# Altium schematic sheet [2]-MCU_LPC1778FBD144.SchDoc([2]-MCU_LPC1778FBD144)
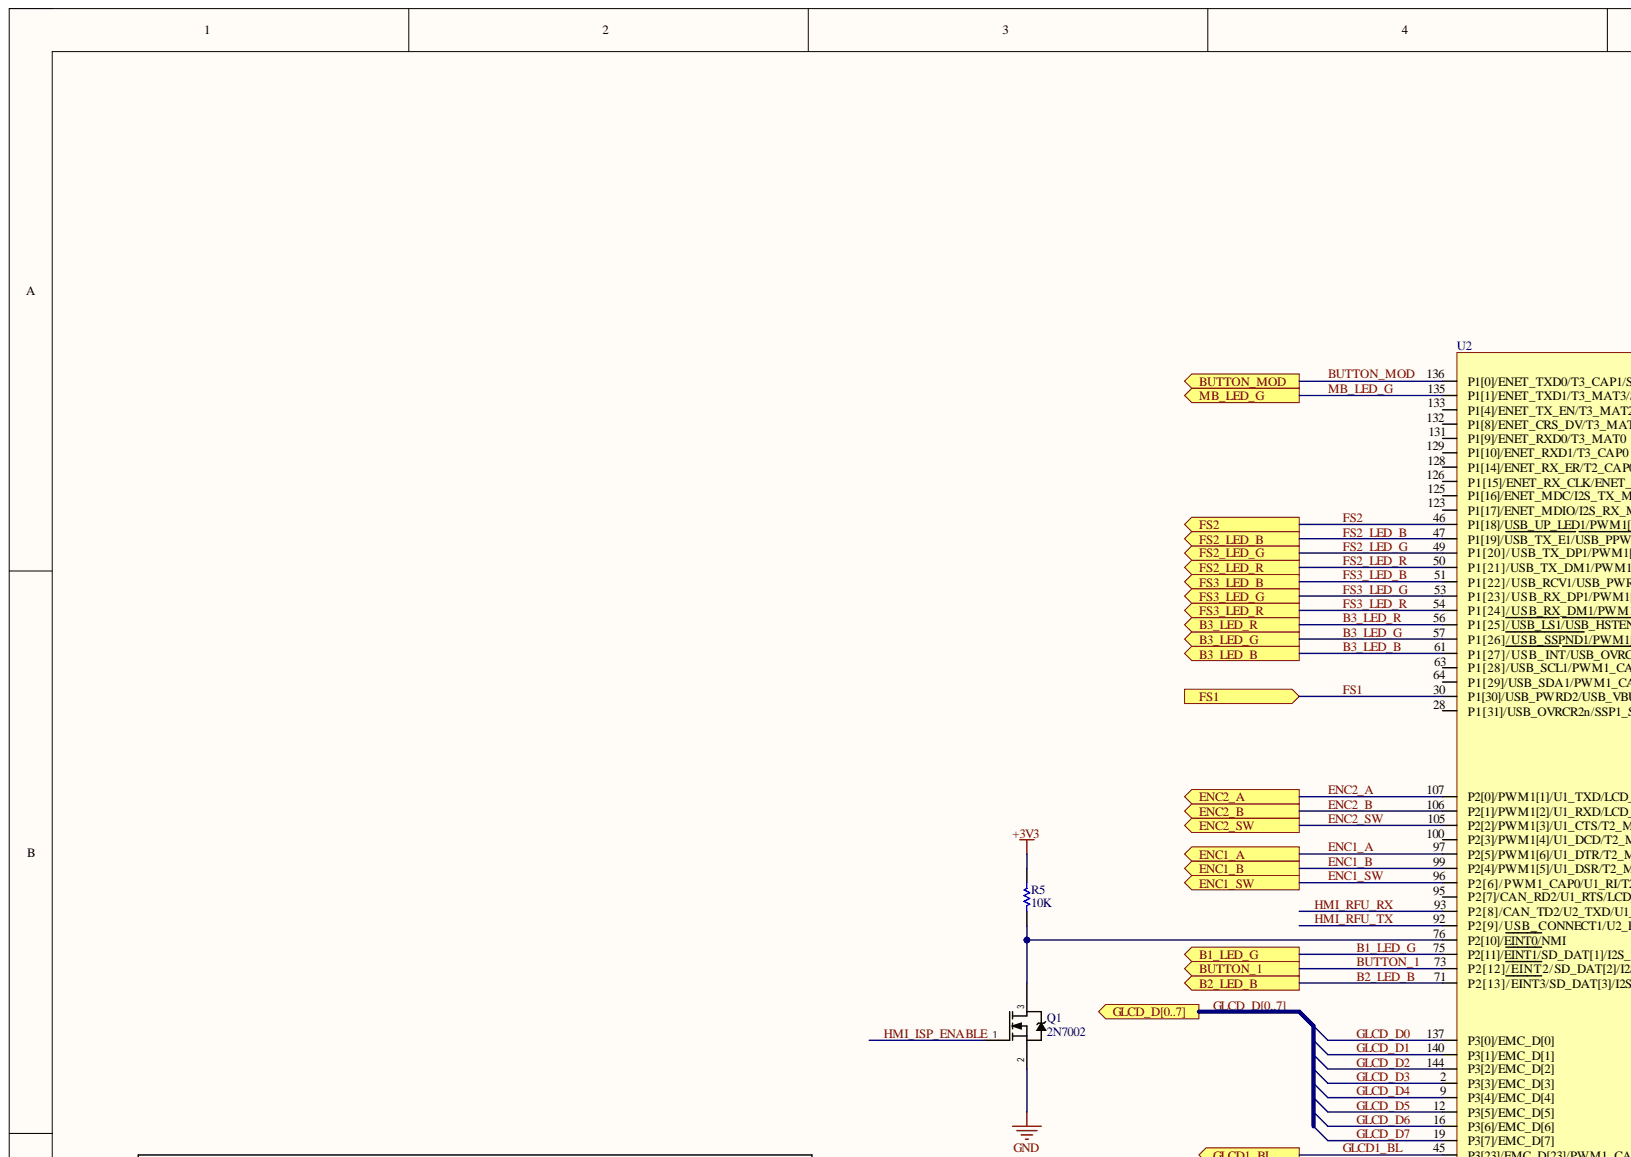
[diagram p0: [2]-MCU_LPC1778FBD144.SchDoc, top left]
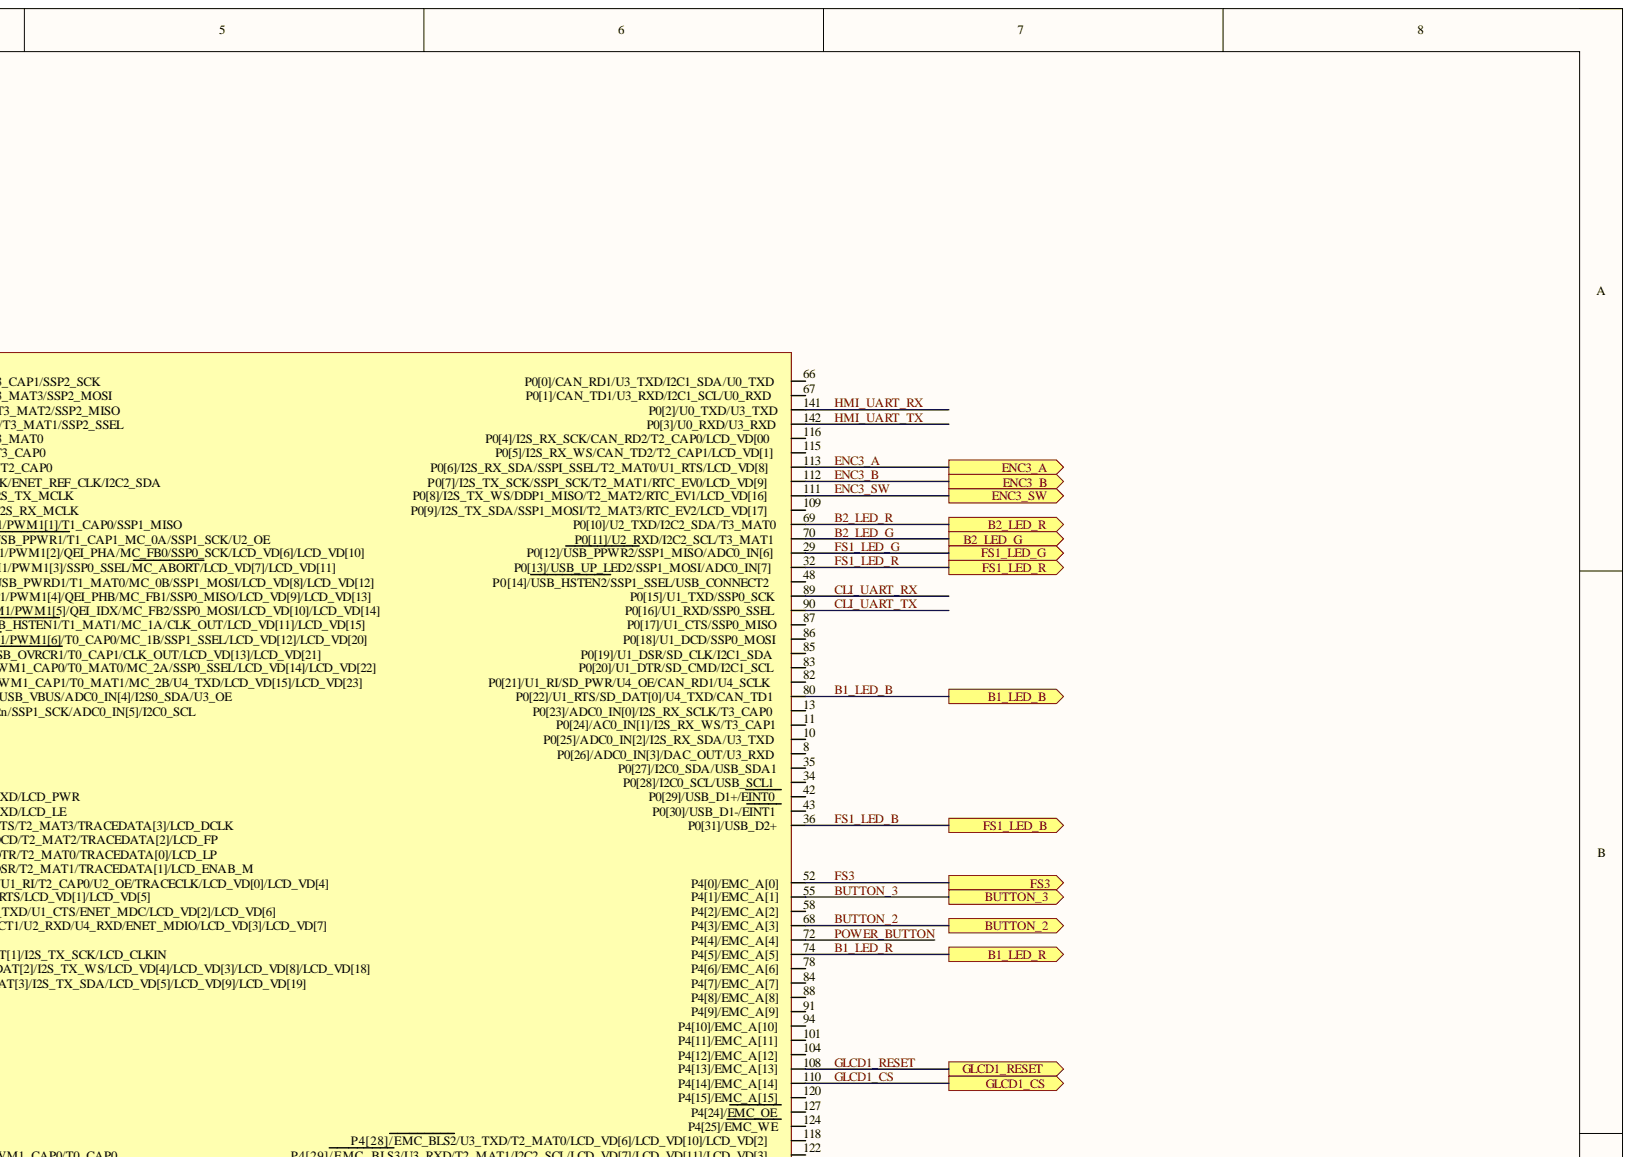
[diagram p1: [2]-MCU_LPC1778FBD144.SchDoc, top right]
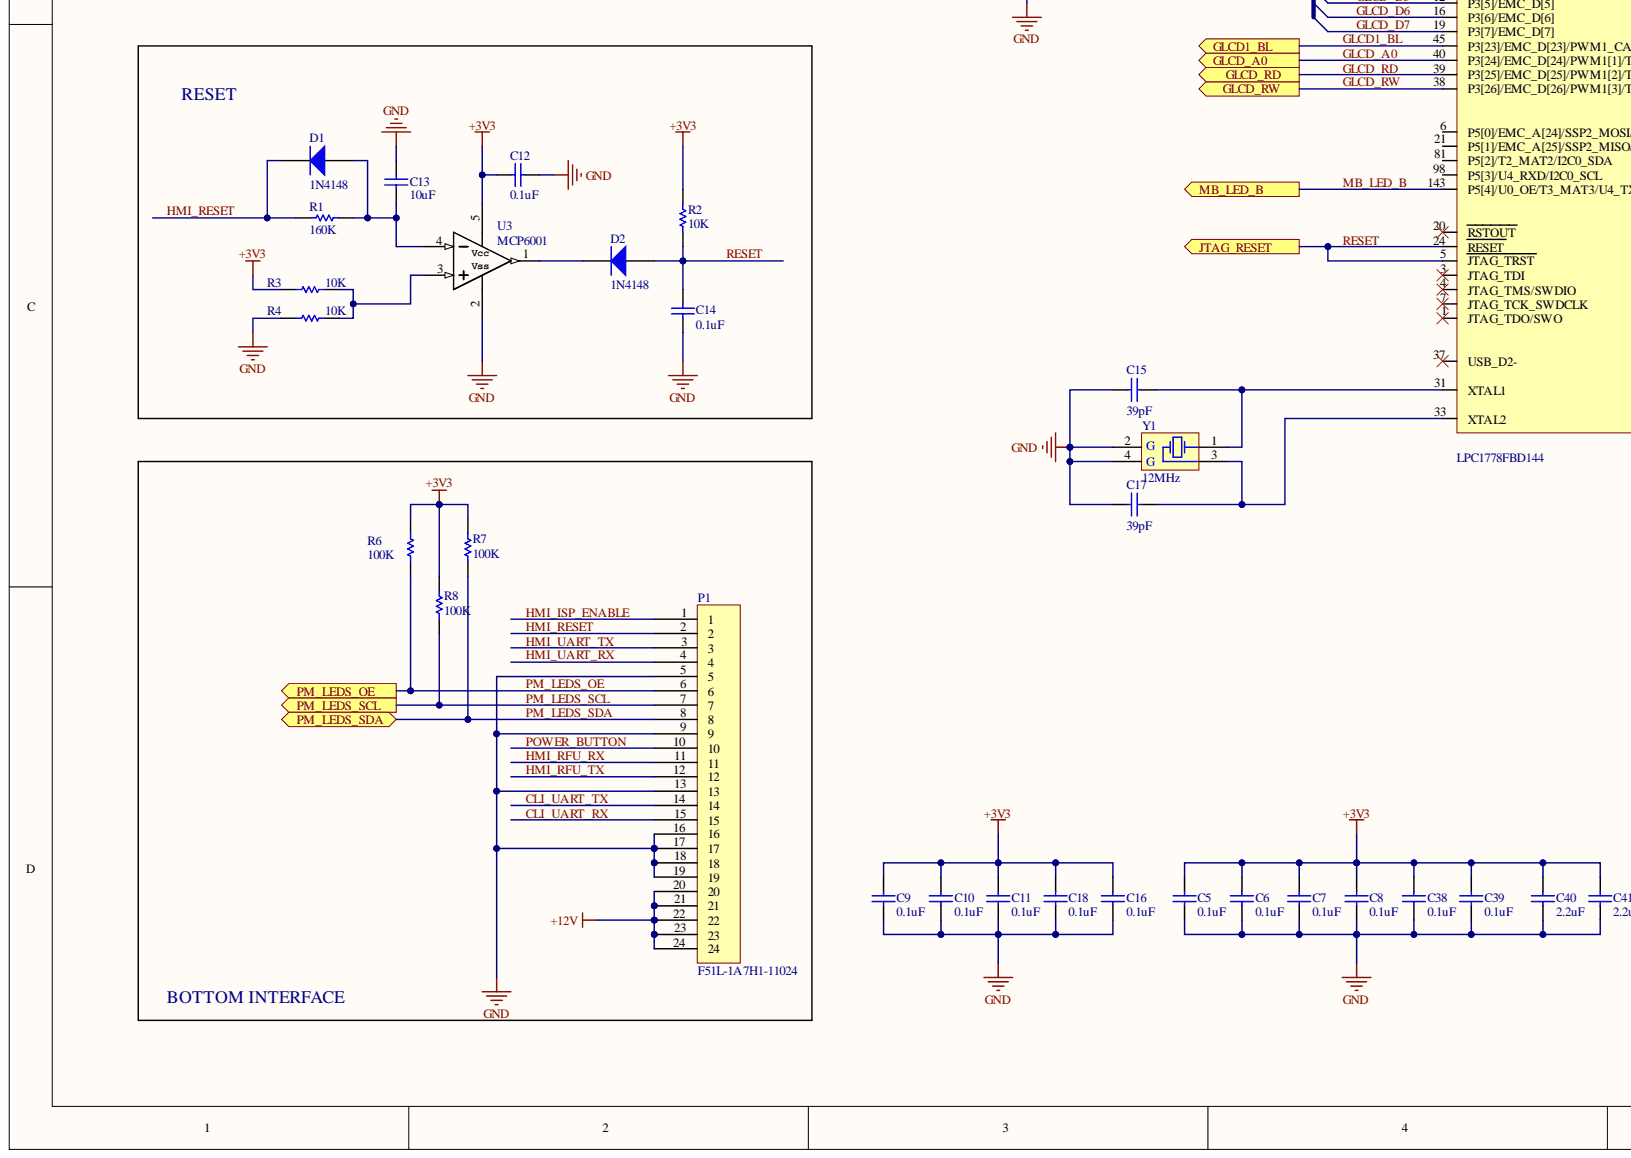
[diagram p2: [2]-MCU_LPC1778FBD144.SchDoc, bottom left]
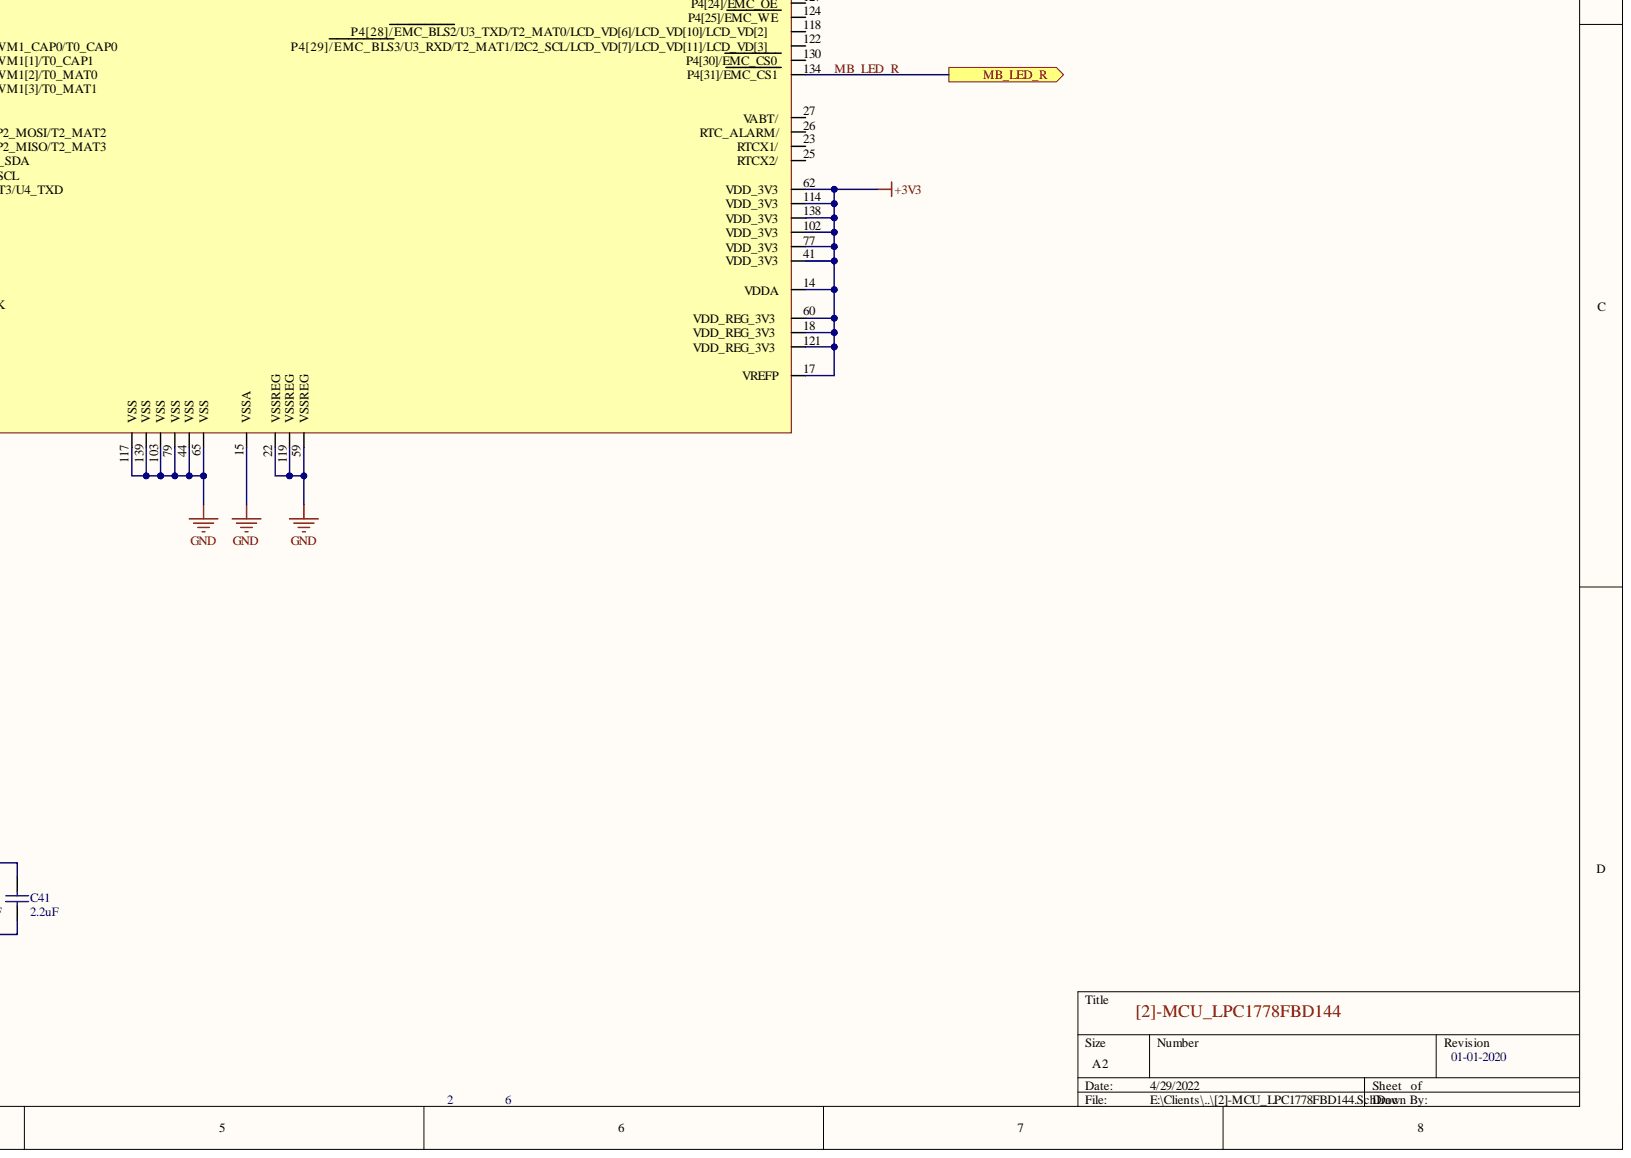
[diagram p3: [2]-MCU_LPC1778FBD144.SchDoc, bottom right]

## Parts
C5  0.1uF  [CAPC1608N]
C6  0.1uF  [CAPC1608N]
C7  0.1uF  [CAPC1608N]
C8  0.1uF  [CAPC1608N]
C9  0.1uF  [CAPC1608N]
C10  0.1uF  [CAPC1608N]
C11  0.1uF  [CAPC1608N]
C12  0.1uF  [CAPC1608N]
C13  10uF  [CAPC1608N]
C14  0.1uF  [CAPC1608N]
C15  39pF  [CAPC1608N]
C16  0.1uF  [CAPC1608N]
C17  39pF  [CAPC1608N]
C18  0.1uF  [CAPC1608N]
C38  0.1uF  [CAPC1608N]
C39  0.1uF  [CAPC1608N]
C40  2.2uF  [CAPC1608N]
C41  2.2uF  [CAPC1608N]
D1  1N4148  [SOD-323]
D2  1N4148  [SOD-323]
P1  F51L-1A7H1-11024  [F51L-1A7H1-11024]
Q1  2N7002  [SOT23-3N]
R1  160K  [RESC1608N]
R2  10K  [RESC1608N]
R3  10K  [RESC1608N]
R4  10K  [RESC1608N]
R5  10K  [RESC1608N]
R6  100K  [RESC1608N]
R7  100K  [RESC1608N]
R8  100K  [RESC1608N]
U2  LPC1778FBD144  [LQFP144-SOT486-1]
U3  MCP6001  [SOT23-5N]
Y1  12MHz  [ECS-120-20-30B-AGL-TR]

## Ports
B1_LED_B, B1_LED_G, B1_LED_R, B2_LED_B, B2_LED_G, B2_LED_R, B3_LED_B, B3_LED_G, B3_LED_R, BUTTON_1, BUTTON_2, BUTTON_3, BUTTON_MOD, ENC1_A, ENC1_B, ENC1_SW, ENC2_A, ENC2_B, ENC2_SW, ENC3_A, ENC3_B, ENC3_SW, FS1, FS1_LED_B, FS1_LED_G, FS1_LED_R, FS2, FS2_LED_B, FS2_LED_G, FS2_LED_R, FS3, FS3_LED_B, FS3_LED_G, FS3_LED_R, GLCD1_BL, GLCD1_CS, GLCD1_RESET, GLCD_A0, GLCD_D0, GLCD_D1, GLCD_D2, GLCD_D3, GLCD_D4, GLCD_D5, GLCD_D6, GLCD_D7, GLCD_D[0..7], GLCD_RD, GLCD_RW, JTAG_RESET, MB_LED_B, MB_LED_G, MB_LED_R, PM_LEDS_OE, PM_LEDS_SCL, PM_LEDS_SDA

## Nets
+3V3: C5.2 C6.2 C7.2 C8.2 C9.2 C10.2 C11.2 C12.2 C16.2 C18.2 C38.2 C39.2 C40.2 C41.2 R2.1 R3.2 R5.1 R6.2 R7.2 R8.2 U2.14(VDDA) U2.17(VREFP) U2.18(VDD_REG_3V3) U2.41(VDD_3V3) U2.60(VDD_REG_3V3) U2.62(VDD_3V3) U2.77(VDD_3V3) U2.102(VDD_3V3) U2.114(VDD_3V3) U2.121(VDD_REG_3V3) U2.138(VDD_3V3) U3.5
+12V: P1.20 P1.21 P1.22 P1.23 P1.24
GND: C5.1 C6.1 C7.1 C8.1 C9.1 C10.1 C11.1 C12.1 C13.1 C14.2 C15.2 C16.1 C17.1 C18.1 C38.1 C39.1 C40.1 C41.1 P1.5 P1.9 P1.13 P1.16 P1.17 P1.18 P1.19 Q1.2 R4.2 U2.15(VSSA) U2.22(VSSREG) U2.44(VSS) U2.59(VSSREG) U2.65(VSS) U2.79(VSS) U2.103(VSS) U2.117(VSS) U2.119(VSSREG) U2.139(VSS) U3.2 Y1.2(G) Y1.4(G)
B1_LED_B: U2.80(P0[22]/U1_RTS/SD_DAT[0]/U4_TXD/CAN_TD1) ; port B1_LED_B
B1_LED_G: U2.75(P2[11]/EINT1/SD_DAT[1]/I2S_TX_SCK/LCD_CLKIN) ; port B1_LED_G
B1_LED_R: U2.74(P4[5]/EMC_A[5]) ; port B1_LED_R
B2_LED_B: U2.71(P2[13]/_EINT3/SD_DAT[3]/I2S_TX_SDA/LCD_VD[5]/LCD_VD[9]/LCD_VD[19]) ; port B2_LED_B
B2_LED_G: U2.70(P0[11]/U2_RXD/I2C2_SCL/T3_MAT1) ; port B2_LED_G
B2_LED_R: U2.69(P0[10]/U2_TXD/I2C2_SDA/T3_MAT0) ; port B2_LED_R
B3_LED_B: U2.61(P1[27]/_USB__INT/USB_OVRCR1/T0_CAP1/CLK_OUT/LCD_VD[13]/LCD_VD[21]) ; port B3_LED_B
B3_LED_G: U2.57(P1[26]/_USB_SSPND1/PWM1[6]/T0_CAP0/MC_1B/SSP1_SSEL/LCD_VD[12]/LCD_VD[20]) ; port B3_LED_G
B3_LED_R: U2.56(P1[25]/_USB_LS1/USB_HSTEN1/T1_MAT1/MC_1A/CLK_OUT/LCD_VD[11]/LCD_VD[15]) ; port B3_LED_R
BUTTON_1: U2.73(P2[12]/_EINT2/_SD_DAT[2]/I2S_TX_WS/LCD_VD[4]/LCD_VD[3]/LCD_VD[8]/LCD_VD[18]) ; port BUTTON_1
BUTTON_2: U2.68(P4[3]/EMC_A[3]) ; port BUTTON_2
BUTTON_3: U2.55(P4[1]/EMC_A[1]) ; port BUTTON_3
BUTTON_MOD: U2.136(P1[0]/ENET_TXD0/T3_CAP1/SSP2_SCK) ; port BUTTON_MOD
CLI_UART_RX: P1.15 U2.89(P0[15]/U1_TXD/SSP0_SCK)
CLI_UART_TX: P1.14 U2.90(P0[16]/U1_RXD/SSP0_SSEL)
ENC1_A: U2.97(P2[5]/PWM1[6]/U1_DTR/T2_MAT0/TRACEDATA[0]/LCD_LP) ; port ENC1_A
ENC1_B: U2.99(P2[4]/PWM1[5]/U1_DSR/T2_MAT1/TRACEDATA[1]/LCD_ENAB_M) ; port ENC1_B
ENC1_SW: U2.96(P2[6]/_PWM1_CAP0/U1_RI/T2_CAP0/U2_OE/TRACECLK/LCD_VD[0]/LCD_VD[4]) ; port ENC1_SW
ENC2_A: U2.107(P2[0]/PWM1[1]/U1_TXD/LCD_PWR) ; port ENC2_A
ENC2_B: U2.106(P2[1]/PWM1[2]/U1_RXD/LCD_LE) ; port ENC2_B
ENC2_SW: U2.105(P2[2]/PWM1[3]/U1_CTS/T2_MAT3/TRACEDATA[3]/LCD_DCLK) ; port ENC2_SW
ENC3_A: U2.113(P0[6]/I2S_RX_SDA/SSPI_SSEL/T2_MAT0/U1_RTS/LCD_VD[8]) ; port ENC3_A
ENC3_B: U2.112(P0[7]/I2S_TX_SCK/SSPI_SCK/T2_MAT1/RTC_EV0/LCD_VD[9]) ; port ENC3_B
ENC3_SW: U2.111(P0[8]/I2S_TX_WS/DDP1_MISO/T2_MAT2/RTC_EV1/LCD_VD[16]) ; port ENC3_SW
FS1: U2.30(P1[30]/USB_PWRD2/USB_VBUS/ADC0_IN[4]/I2S0_SDA/U3_OE) ; port FS1
FS1_LED_B: U2.36(P0[31]/USB_D2+) ; port FS1_LED_B
FS1_LED_G: U2.29(P0[12]/USB_PPWR2/SSP1_MISO/ADC0_IN[6]) ; port FS1_LED_G
FS1_LED_R: U2.32(P0[13]/USB_UP_LED2/SSP1_MOSI/ADC0_IN[7]) ; port FS1_LED_R
FS2: U2.46(P1[18]/USB_UP_LED1/PWM1[1]/T1_CAP0/SSP1_MISO) ; port FS2
FS2_LED_B: U2.47(P1[19]/USB_TX_E1/USB_PPWR1/T1_CAP1_MC_0A/SSP1_SCK/U2_OE) ; port FS2_LED_B
FS2_LED_G: U2.49(P1[20]/_USB_TX_DP1/PWM1[2]/QEI_PHA/MC_FB0/SSP0_SCK/LCD_VD[6]/LCD_VD[10]) ; port FS2_LED_G
FS2_LED_R: U2.50(P1[21]/USB_TX_DM1/PWM1[3]/SSP0_SSEL/MC_ABORT/LCD_VD[7]/LCD_VD[11]) ; port FS2_LED_R
FS3: U2.52(P4[0]/EMC_A[0]) ; port FS3
FS3_LED_B: U2.51(P1[22]/_USB_RCV1/USB_PWRD1/T1_MAT0/MC_0B/SSP1_MOSI/LCD_VD[8]/LCD_VD[12]) ; port FS3_LED_B
FS3_LED_G: U2.53(P1[23]/_USB_RX_DP1/PWM1[4]/QEI_PHB/MC_FB1/SSP0_MISO/LCD_VD[9]/LCD_VD[13]) ; port FS3_LED_G
FS3_LED_R: U2.54(P1[24]/_USB_RX_DM1/PWM1[5]/QEI_IDX/MC_FB2/SSP0_MOSI/LCD_VD[10]/LCD_VD[14]) ; port FS3_LED_R
GLCD1_BL: U2.45(P3[23]/EMC_D[23]/PWM1_CAP0/T0_CAP0) ; port GLCD1_BL
GLCD1_CS: U2.110(P4[14]/EMC_A[14]) ; port GLCD1_CS
GLCD1_RESET: U2.108(P4[13]/EMC_A[13]) ; port GLCD1_RESET
GLCD_A0: U2.40(P3[24]/EMC_D[24]/PWM1[1]/T0_CAP1) ; port GLCD_A0
GLCD_D0: U2.137(P3[0]/EMC_D[0]) ; port GLCD_D[0..7]  [also labelled GLCD_D]
GLCD_D1: U2.140(P3[1]/EMC_D[1]) ; port GLCD_D[0..7]  [also labelled GLCD_D]
GLCD_D2: U2.144(P3[2]/EMC_D[2]) ; port GLCD_D[0..7]  [also labelled GLCD_D]
GLCD_D3: U2.2(P3[3]/EMC_D[3]) ; port GLCD_D[0..7]  [also labelled GLCD_D]
GLCD_D4: U2.9(P3[4]/EMC_D[4]) ; port GLCD_D[0..7]  [also labelled GLCD_D]
GLCD_D5: U2.12(P3[5]/EMC_D[5]) ; port GLCD_D[0..7]  [also labelled GLCD_D]
GLCD_D6: U2.16(P3[6]/EMC_D[6]) ; port GLCD_D[0..7]  [also labelled GLCD_D]
GLCD_D7: U2.19(P3[7]/EMC_D[7]) ; port GLCD_D[0..7]  [also labelled GLCD_D]
GLCD_RD: U2.39(P3[25]/EMC_D[25]/PWM1[2]/T0_MAT0) ; port GLCD_RD
GLCD_RW: U2.38(P3[26]/EMC_D[26]/PWM1[3]/T0_MAT1) ; port GLCD_RW
HMI_ISP_ENABLE: P1.1 Q1.1
HMI_RESET: D1.1 P1.2 R1.1
HMI_RFU_RX: P1.11 U2.93(P2[8]/CAN_TD2/U2_TXD/U1_CTS/ENET_MDC/LCD_VD[2]/LCD_VD[6])
HMI_RFU_TX: P1.12 U2.92(P2[9]/_USB__CONNECT1/U2_RXD/U4_RXD/ENET_MDIO/LCD_VD[3]/LCD_VD[7])
HMI_UART_RX: P1.4 U2.141(P0[2]/U0_TXD/U3_TXD)
HMI_UART_TX: P1.3 U2.142(P0[3]/U0_RXD/U3_RXD)
MB_LED_B: U2.143(P5[4]/U0_OE/T3_MAT3/U4_TXD) ; port MB_LED_B
MB_LED_G: U2.135(P1[1]/ENET_TXD1/T3_MAT3/SSP2_MOSI) ; port MB_LED_G
MB_LED_R: U2.134(P4[31]/EMC_CS1) ; port MB_LED_R
PM_LEDS_OE: P1.6 R6.1 ; port PM_LEDS_OE
PM_LEDS_SCL: P1.7 R8.1 ; port PM_LEDS_SCL
PM_LEDS_SDA: P1.8 R7.1 ; port PM_LEDS_SDA
POWER_BUTTON: P1.10 U2.72(P4[4]/EMC_A[4])
RESET: C14.1 D2.2 R2.2 U2.5(JTAG_TRST) U2.24(RESET) ; port JTAG_RESET
NetC13_2: C13.2 D1.2 R1.2 U3.4
NetC15_1: C15.1 U2.31(XTAL1) Y1.1
NetC17_2: C17.2 U2.33(XTAL2) Y1.3
NetD2_1: D2.1 U3.1
NetQ1_3: Q1.3 R5.2 U2.76(P2[10]/EINT0/NMI)
NetR3_1: R3.1 R4.1 U3.3

Not connected: U2 1(JTAG_TDO/SWO) 3(JTAG_TDI) 4(JTAG_TMS/SWDIO) 6(P5[0]/EMC_A[24]/SSP2_MOSI/T2_MAT2) 7(JTAG_TCK_SWDCLK) 8(P0[26]/ADC0_IN[3]/DAC_OUT/U3_RXD) 10(P0[25]/ADC0_IN[2]/I2S_RX_SDA/U3_TXD) 11(P0[24]/AC0_IN[1]/I2S_RX_WS/T3_CAP1) 13(P0[23]/ADC0_IN[0]/I2S_RX_SCLK/T3_CAP0) 20(RSTOUT) 21(P5[1]/EMC_A[25]/SSP2_MISO/T2_MAT3) 23(RTCX1/) 25(RTCX2/) 26(RTC_ALARM/) 27(VABT/) 28(P1[31]/USB_OVRCR2n/SSP1_SCK/ADC0_IN[5]/I2C0_SCL) 34(P0[28]/I2C0_SCL/USB_SCL1) 35(P0[27]/I2C0_SDA/USB_SDA1) 37(USB_D2-) 42(P0[29]/USB_D1+/EINT0) 43(P0[30]/USB_D1-/EINT1) 48(P0[14]/USB_HSTEN2/SSP1_SSEL/USB_CONNECT2) 58(P4[2]/EMC_A[2]) 63(P1[28]/USB_SCL1/PWM1_CAP0/T0_MAT0/MC_2A/SSP0_SSEL/LCD_VD[14]/LCD_VD[22]) 64(P1[29]/USB_SDA1/PWM1_CAP1/T0_MAT1/MC_2B/U4_TXD/LCD_VD[15]/LCD_VD[23]) 66(P0[0]/CAN_RD1/U3_TXD/I2C1_SDA/U0_TXD) 67(P0[1]/CAN_TD1/U3_RXD/I2C1_SCL/U0_RXD) 78(P4[6]/EMC_A[6]) 81(P5[2]/T2_MAT2/I2C0_SDA) 82(P0[21]/U1_RI/SD_PWR/U4_OE/CAN_RD1/U4_SCLK) 83(P0[20]/U1_DTR/SD_CMD/I2C1_SCL) 84(P4[7]/EMC_A[7]) 85(P0[19]/U1_DSR/SD_CLK/I2C1_SDA) 86(P0[18]/U1_DCD/SSP0_MOSI) 87(P0[17]/U1_CTS/SSP0_MISO) 88(P4[8]/EMC_A[8]) 91(P4[9]/EMC_A[9]) 94(P4[10]/EMC_A[10]) 95(P2[7]/CAN_RD2/U1_RTS/LCD_VD[1]/LCD_VD[5]) 98(P5[3]/U4_RXD/I2C0_SCL) 100(P2[3]/PWM1[4]/U1_DCD/T2_MAT2/TRACEDATA[2]/LCD_FP) 101(P4[11]/EMC_A[11]) 104(P4[12]/EMC_A[12]) 109(P0[9]/I2S_TX_SDA/SSP1_MOSI/T2_MAT3/RTC_EV2/LCD_VD[17]) 115(P0[5]/I2S_RX_WS/CAN_TD2/T2_CAP1/LCD_VD[1]) 116(P0[4]/I2S_RX_SCK/CAN_RD2/T2_CAP0/LCD_VD[00) 118(P4[28]/_EMC_BLS2/U3_TXD/T2_MAT0/LCD_VD[6]/LCD_VD[10]/LCD_VD[2]) 120(P4[15]/EMC_A[15]) 122 123(P1[17]/ENET_MDIO/I2S_RX_MCLK) 124(P4[25]/EMC_WE) 125(P1[16]/ENET_MDC/I2S_TX_MCLK) 126(P1[15]/ENET_RX_CLK/ENET_REF_CLK/I2C2_SDA) 127(P4[24]/EMC_OE) 128(P1[14]/ENET_RX_ER/T2_CAP0) 129(P1[10]/ENET_RXD1/T3_CAP0) 130(P4[30]/EMC_CS0) 131(P1[9]/ENET_RXD0/T3_MAT0) 132(P1[8]/ENET_CRS_DV/T3_MAT1/SSP2_SSEL) 133(P1[4]/ENET_TX_EN/T3_MAT2/SSP2_MISO)

## Library data of the parts
C5-C12 C14 C16 C18 C38 C39 = CC0603KRX7R8BB104: CAP CER 0.1UF 25V X7R 0603; Manufacturer 1: Yageo; Capacitance: 100nF; Tolerance: 10%; Case Code (Imperial): 0603; Case Code (Metric): 1608; Case/Package: 0603; Component Kind: Standard; ComponentLink1Description: https://datasheet.ciiva.com/11786/0900766b80c10176-11786120.pdf; ComponentLink1URL: https://datasheet.ciiva.com/11786/0900766b80c10176-11786120.pdf; ComponentLink2Description: https://datasheet.ciiva.com/144/mlccorderingcode-144075.pdf; ComponentLink2URL: https://datasheet.ciiva.com/144/mlccorderingcode-144075.pdf; ComponentLink3Description: https://datasheet.ciiva.com/17434/upy-gphc-x7r-6-3v-to-50v-8-17434263.pdf; ComponentLink3URL: https://datasheet.ciiva.com/17434/upy-gphc-x7r-6-3v-to-50v-8-17434263.pdf; ComponentLink4Description: https://datasheet.ciiva.com/26829/getdatasheetpartid-403577-26829431.pdf; ComponentLink4URL: https://datasheet.ciiva.com/26829/getdatasheetpartid-403577-26829431.pdf; ComponentLink5Description: https://datasheet.ciiva.com/11587/upy-gphc-x7r-6-3v-to-50v-8-11587208.pdf; ComponentLink5URL: https://datasheet.ciiva.com/11587/upy-gphc-x7r-6-3v-to-50v-8-11587208.pdf; ComponentLink6Description: https://datasheet.ciiva.com/13389/upy-gphc-x7r-6-3v-to-50v-8-13389352.pdf; ComponentLink6URL: https://datasheet.ciiva.com/13389/upy-gphc-x7r-6-3v-to-50v-8-13389352.pdf; ComponentLink7Description: https://datasheet.ciiva.com/28450/1489800-28450584.pdf; ComponentLink7URL: https://datasheet.ciiva.com/28450/1489800-28450584.pdf; ComponentLink8Description: https://datasheet.ciiva.com/144/mlccorderingcode-144073.pdf; ComponentLink8URL: https://datasheet.ciiva.com/144/mlccorderingcode-144073.pdf; ComponentLink9Description: https://datasheet.ciiva.com/144/mlccorderingcode-144074.pdf; ComponentLink9URL: https://datasheet.ciiva.com/144/mlccorderingcode-144074.pdf; ComponentLink10Description: https://datasheet.ciiva.com/7978/1489800-7978155.pdf; ComponentLink10URL: https://datasheet.ciiva.com/7978/1489800-7978155.pdf; Composition: Ceramic; Depth: 0.8mm; Dielectric: X7R; Halogen Free: Halogen Free; Height: 0.8mm; Lead Free: Lead Free; Length: 1.6mm; Manufacturer Part Number 1: CC0603KRX7R8BB104; Max Operating Temperature: 125°C; Min Operating Temperature: -55°C; Mount: Surface Mount; Number of Pins: 2; Package Quantity: 1; Packaging: Tape and Reel; Pricing 1: 1=0.1, 10=0.074, 100=0.0329, 500=0.02352, 1000=0.01848 (USD); Radiation Hardening: No; REACH SVHC: Unknown; Stock 1: 40000; Supplier 1: Digi-Key; Supplier Part Number 1: 311-1341-1-ND; Termination: SMD/SMT; Thickness: 0.035inch; Voltage: 25V; Voltage Rating: 25V; Voltage Rating (DC): 25V; Width: 0.8mm; Library Name: bitsnio.SchLib
C13 = GRM188R61A106KE69D: CAP CER 10UF 10V X5R 0603; Manufacturer 1: Murata; Tolerance: 10%; Capacitance: 10uF; Case Code (Imperial): 0603; Case Code (Metric): 1608; Case/Package: 0603; Component Kind: Standard; Depth: 0.8mm; Dielectric: X5R; Height: 0.8mm; Lead Free: Lead Free; Length: 1.6mm; Manufacturer Part Number 1: GRM188R61A106KE69D; Max Operating Temperature: 85°C; Min Operating Temperature: -55°C; Mount: Surface Mount; Packaging: Tape and Reel; Pricing 1: 1=0.22, 10=0.149, 100=0.0754, 500=0.05158, 1000=0.04563 (USD); REACH SVHC: No SVHC; Stock 1: 40000; Supplier 1: Digi-Key; Supplier Part Number 1: 490-10474-1-ND; Thickness: 0.037inch; Voltage: 10V; Voltage Rating: 10V; Voltage Rating (DC): 10V; Width: 0.031inch; Library Name: bitsnio.SchLib
C15 C17 = C1608NP01H390J080AA: CAP CER 39PF 50V NP0 0603; Manufacturer 1: TDK; Dielectric: C0G; Tolerance: 5%; Capacitance: 39pF; Case Code (Imperial): 0603; Case Code (Metric): 1608; Case/Package: 0603; Component Kind: Standard; Height: 0.8mm; Length: 0.063inch; Manufacturer Part Number 1: C1608NP01H390J080AA; Max Operating Temperature: 150°C; Min Operating Temperature: -55°C; Mount: Surface Mount; Package Quantity: 4000; Packaging: Tape and Reel; Pricing 1: 1=0.15, 10=0.106, 100=0.05, 500=0.03508, 1000=0.02904 (USD); Stock 1: 1503; Supplier 1: Digi-Key; Supplier Part Number 1: 445-14077-1-ND; Termination: SMD/SMT; Thickness: 0.035inch; Voltage Rating: 50V; Voltage Rating (DC): 50V; Weight: 7.1E-05oz; Width: 0.031inch; Library Name: bitsnio.SchLib
C40 C41 = CL10A225KP8NNNC: CAP CER 2.2UF 10V X5R 0603; Manufacturer 1: Samsung; Material: Ceramic; Tolerance: 10%; Capacitance: 2.2uF; Case Code (Imperial): 0603; Case Code (Metric): 1608; Case/Package: 0603; Component Kind: Standard; ComponentLink1Description: https://datasheet.ciiva.com/25799/cl10a225kp8nnnc-25799697.pdf?src-supplier=Digi-Key; ComponentLink1URL: https://datasheet.ciiva.com/25799/cl10a225kp8nnnc-25799697.pdf?src-supplier=Digi-Key; ComponentLink2Description: https://datasheet.ciiva.com/26838/getdatasheetpartid-358617-26838359.pdf?src-supplier=Verical; ComponentLink2URL: https://datasheet.ciiva.com/26838/getdatasheetpartid-358617-26838359.pdf?src-supplier=Verical; ComponentLink3Description: https://datasheet.ciiva.com/29198/457945-da-01-en-vs-kondensator-0603-29198592.pdf?src-supplier=Conrad; ComponentLink3URL: https://datasheet.ciiva.com/29198/457945-da-01-en-vs-kondensator-0603-29198592.pdf?src-supplier=Conrad; Depth: 0.8mm; Dielectric: X5R; Height: 0.8mm; Lead Free: Lead Free; Length: 1.6mm; Manufacturer Part Number: CL10A225KP8NNNC; Max Operating Temperature: 85°C; Min Operating Temperature: -55°C; Mount: Surface Mount; Package Quantity: 1; Packaging: Tape and Reel; Pricing 1: 1=0.10000, 10=0.07600, 100=0.03400, 500=0.02426, 1000=0.01906 (USD); Radiation Hardening: No; RoHS: Yes; Stock 1: 320107; Supplier 1: Digi-Key; Supplier Part Number 1: 1276-1085-1-ND; Thickness: 0.035inch; Voltage: 10V; Voltage Rating: 10V; Voltage Rating (DC): 10V; Width: 0.031inch; Library Name: bitsnio.SchLib
D1 D2 = 1N4148WS-7-F: DIODE SWITCHING 75V 0.15A SOD323; Manufacturer 1: Diodes; Average Rectified Current: 150mA; Capacitance: 2pF; Current: 2A; Element Configuration: Single; Forward Current: 300mA; Forward Voltage: 1.25V; Peak Reverse Current: 1uA; Polarity: Standard; Power Dissipation: 200mW; Recovery Time: 4ns; Component Kind: Standard; ComponentLink1Description: https://datasheet.ciiva.com/16199/ds30097-16199061.pdf; ComponentLink1URL: https://datasheet.ciiva.com/16199/ds30097-16199061.pdf; ComponentLink2Description: https://datasheet.ciiva.com/16472/ds30097-16472599.pdf; ComponentLink2URL: https://datasheet.ciiva.com/16472/ds30097-16472599.pdf; ComponentLink3Description: https://datasheet.ciiva.com/1179/ds30097-1179355.pdf; ComponentLink3URL: https://datasheet.ciiva.com/1179/ds30097-1179355.pdf; ComponentLink4Description: https://datasheet.ciiva.com/8300/ds30097-8300396.pdf; ComponentLink4URL: https://datasheet.ciiva.com/8300/ds30097-8300396.pdf; ComponentLink5Description: https://datasheet.ciiva.com/911/ds30097-911741.pdf; ComponentLink5URL: https://datasheet.ciiva.com/911/ds30097-911741.pdf; ComponentLink6Description: https://datasheet.ciiva.com/911/ds30097-911742.pdf; ComponentLink6URL: https://datasheet.ciiva.com/911/ds30097-911742.pdf; ComponentLink7Description: https://datasheet.ciiva.com/25946/ds30097-25946926.pdf; ComponentLink7URL: https://datasheet.ciiva.com/25946/ds30097-25946926.pdf; ComponentLink8Description: https://datasheet.ciiva.com/911/ds30097-911743.pdf; ComponentLink8URL: https://datasheet.ciiva.com/911/ds30097-911743.pdf; Contact Plating: Tin,Matte; Current Rating: 150mA; Height: 1mm; Lead Free: Lead Free; Length: 1.8mm; Manufacturer Part Number 1: 1N4148WS-7-F; Max Forward Surge Current (Ifsm): 2A; Max Operating Temperature: 150°C; Max Repetitive Reverse Voltage (Vrrm): 75V; Max Reverse Voltage (DC): 75V; Max Surge Current: 2A; Min Operating Temperature: -65°C; Mount: Surface Mount; Number of Pins: 2; Output Current: 150mA; Package Quantity: 3000; Packaging: Tape and Reel; Peak Non-Repetitive Surge Current: 2A; Power Rating: 200mW; Pricing 1: 1=0.19, 10=0.171, 100=0.0932, 500=0.05736, 1000=0.03911 (USD); Radiation Hardening: No; REACH SVHC: No SVHC; Reverse Recovery Time: 4ns; Stock 1: 557451; Supplier 1: Digi-Key; Supplier Part Number 1: 1N4148WS-FDICT-ND; Voltage: 75V; Voltage Rating (DC): 75V; Weight: 0.000173oz; Width: 1.4mm; Library Name: bitsnio.SchLib
P1 = F51L-1A7H1-11024: FLEX CONNECTOR, 1.00MM PITCH, HE; Manufacturer 1: Amphenol ICC / FCI; Category: FFC / FPC; Component Kind: Standard; Manufacturer Part Number 1: F51L-1A7H1-11024; Pricing 1: 1=0.77000, 10=0.67500, 25=0.61680, 50=0.58060, 100=0.55640, 250=0.50804, 500=0.48384 (USD); Stock 1: 1646; Supplier 1: Digi-Key; Supplier Part Number 1: 609-F51L-1A7H1-11024CT-ND; Library Name: bitsnio.SchLib
Q1 = 2N7002: MOSFET N-CH 60V 115MA SOT-23; Manufacturer 1: ON Semiconductor / Fairchild; Drain to Source Resistance: 1.2R; Element Configuration: Single; Gate to Source Voltage (Vgs): 20V; Input Capacitance: 50pF; Max Power Dissipation: 200mW; Nominal Vgs: 2.1V; Number of Elements: 1; On-State Resistance: 5.3R; Rds On Max: 7.5R; Case/Package: SOT-23; Component Kind: Standard; ComponentLink1Description: https://datasheet.ciiva.com/931/2n7000-931777.pdf; ComponentLink1URL: https://datasheet.ciiva.com/931/2n7000-931777.pdf; ComponentLink2Description: https://datasheet.ciiva.com/27746/47-0120-27746881.pdf; ComponentLink2URL: https://datasheet.ciiva.com/27746/47-0120-27746881.pdf; ComponentLink3Description: https://datasheet.ciiva.com/1254/2n7002-1254451.pdf; ComponentLink3URL: https://datasheet.ciiva.com/1254/2n7002-1254451.pdf; ComponentLink4Description: https://datasheet.ciiva.com/15137/getdatasheetpartid-93547-15137771.pdf; ComponentLink4URL: https://datasheet.ciiva.com/15137/getdatasheetpartid-93547-15137771.pdf; ComponentLink5Description: https://datasheet.ciiva.com/931/2n7000-931762.pdf; ComponentLink5URL: https://datasheet.ciiva.com/931/2n7000-931762.pdf; ComponentLink6Description: https://datasheet.ciiva.com/931/2n7000-931763.pdf; ComponentLink6URL: https://datasheet.ciiva.com/931/2n7000-931763.pdf; ComponentLink7Description: https://datasheet.ciiva.com/931/2n7000-931764.pdf; ComponentLink7URL: https://datasheet.ciiva.com/931/2n7000-931764.pdf; ComponentLink8Description: https://datasheet.ciiva.com/1254/660093-1254452.pdf; ComponentLink8URL: https://datasheet.ciiva.com/1254/660093-1254452.pdf; ComponentLink9Description: https://datasheet.ciiva.com/1188/660093-1188359.pdf; ComponentLink9URL: https://datasheet.ciiva.com/1188/660093-1188359.pdf; ComponentLink10Description: https://datasheet.ciiva.com/1188/660093-1188361.pdf; ComponentLink10URL: https://datasheet.ciiva.com/1188/660093-1188361.pdf; ComponentLink11Description: https://datasheet.ciiva.com/17007/2n7002-17007243.pdf; ComponentLink11URL: https://datasheet.ciiva.com/17007/2n7002-17007243.pdf; ComponentLink12Description: https://datasheet.ciiva.com/3304/nds7002a-3304380.pdf; ComponentLink12URL: https://datasheet.ciiva.com/3304/nds7002a-3304380.pdf; ComponentLink13Description: https://datasheet.ciiva.com/3304/nds7002a-3304381.pdf; ComponentLink13URL: https://datasheet.ciiva.com/3304/nds7002a-3304381.pdf; ComponentLink14Description: https://datasheet.ciiva.com/22655/660093-22655230.pdf; ComponentLink14URL: https://datasheet.ciiva.com/22655/660093-22655230.pdf; Contact Plating: Tin,Matte; Continuous Drain Current (ID): 115mA; Current: 115mA; Current Rating: 115mA; Drain to Source Breakdown Voltage: 60V; Drain to Source Voltage (Vdss): 60V; Dual Supply Voltage: 60V; Height: 0.93mm; Lead Free: Lead Free; Length: 2.92mm; Manufacturer Part Number 1: 2N7002; Max Operating Temperature: 150°C; Min Operating Temperature: -55°C; Mount: Surface Mount; Number of Pins: 3; Package Quantity: 1; Packaging: Tape and Reel; Power Dissipation: 200mW; Pricing 1: 1=0.33, 10=0.304, 100=0.17, 500=0.09108, 1000=0.06193 (USD); Radiation Hardening: No; REACH SVHC: No SVHC; Stock 1: 111118; Supplier 1: Digi-Key; Supplier Part Number 1: 2N7002NCT-ND; Threshold Voltage: 2.1V; Voltage: 60V; Voltage Rating (DC): 60V; Width: 3.05mm; Library Name: bitsnio.SchLib
R1 = RMCF0603JT160K: RES SMD 160K OHM 5% 1/10W 0603; Manufacturer 1: Stackpole Electronics; Max Power Dissipation: 100mW; Resistance: 160kR; Temperature Coefficient: 100ppm/°C; Case Code (Imperial): 0603; Case Code (Metric): 1608; Case/Package: 0603; Component Kind: Standard; Composition: Thick Film; Contact Plating: Tin,Matte; Features: Automotive AEC-Q200; Height: 0.022inch; Lead Free: Lead Free; Length: 0.061inch; Manufacturer Part Number 1: RMCF0603JT160K; Max Operating Temperature: 155°C; Min Operating Temperature: -55°C; Mount: Surface Mount; Number of Terminations: 2; Packaging: Tape and Reel; Power Rating: 100mW; Pricing 1: 1=0.1, 10=0.016, 100=0.0064, 1000=0.00289, 2500=0.0025 (USD); Radiation Hardening: No; Stock 1: 10640; Supplier 1: Digi-Key; Supplier Part Number 1: RMCF0603JT160KCT-ND; Tolerance: 5%; Width: 0.031inch; Library Name: bitsnio.SchLib
R2-R5 = AC0603JR-0710KL: RES SMD 10K OHM 5% 1/10W 0603; Manufacturer 1: Yageo; Max Power Dissipation: 100mW; Resistance: 10kR; Temperature Coefficient: 100ppm/°C; Voltage Rating: 50V; Case Code (Imperial): 0603; Case Code (Metric): 1608; Case/Package: 0603; Component Kind: Standard; ComponentLink1Description: https://datasheet.ciiva.com/5562/pyu-ac-51-rohs-l-2-5562553.pdf; ComponentLink1URL: https://datasheet.ciiva.com/5562/pyu-ac-51-rohs-l-2-5562553.pdf; Composition: Thick Film; Depth: 0.8mm; Features: Moisture Resistant,Automotive AEC-Q200,Anti-Sulfur; Height: 0.022inch; Lead Free: Lead Free; Length: 0.063inch; Manufacturer Part Number 1: AC0603JR-0710KL; Max Operating Temperature: 155°C; Military Standard: Not; Min Operating Temperature: -55°C; Number of Terminations: 2; Package Quantity: 1; Packaging: Tape and Reel; Power Rating: 100mW; Pricing 1: 1=0.1, 10=0.032, 100=0.0131, 1000=0.00588, 2500=0.0051 (USD); Stock 1: 60451; Supplier 1: Digi-Key; Supplier Part Number 1: 311-10KLECT-ND; Termination: SMD/SMT; Tolerance: 5%; Width: 0.031inch; Library Name: bitsnio.SchLib
R6-R8 = ESR03EZPJ104: RES SMD 100K OHM 5% 1/4W 0603; Manufacturer 1: Rohm; Max Power Dissipation: 250mW; Power Rating: 200mW; Resistance: 100kR; Temperature Coefficient: 200ppm/°C; Case Code (Imperial): 0603; Case Code (Metric): 1608; Case/Package: 0603; Component Kind: Standard; ComponentLink1Description: https://datasheet.ciiva.com/14930/esr-14930633.pdf; ComponentLink1URL: https://datasheet.ciiva.com/14930/esr-14930633.pdf; ComponentLink2Description: https://datasheet.ciiva.com/14924/esr-14924811.pdf; ComponentLink2URL: https://datasheet.ciiva.com/14924/esr-14924811.pdf; ComponentLink3Description: https://datasheet.ciiva.com/14928/esr-14928813.pdf; ComponentLink3URL: https://datasheet.ciiva.com/14928/esr-14928813.pdf; Composition: Thick Film; Height: 0.022inch; Lead Free: Lead Free; Length: 0.063inch; Manufacturer Part Number 1: ESR03EZPJ104; Max Operating Temperature: 155°C; Min Operating Temperature: -55°C; Number of Terminations: 2; Package Quantity: 1; Packaging: Cut Tape; Pricing 1: 1=0.1, 10=0.087, 100=0.0333, 1000=0.01464, 2500=0.01264 (USD); Stock 1: 17901; Supplier 1: Digi-Key; Supplier Part Number 1: RHM100KDCT-ND; Tolerance: 5%; Width: 0.031inch; Library Name: bitsnio.SchLib
U2 = LPC1778FBD144,551: IC MCU 32BIT 512KB FLASH 144LQFP; Manufacturer 1: NXP Semiconductors; Core Architecture: ARM; Data Bus Width: 32b; Frequency: 120MHz; Interface: I2C,SPI,USB,CAN,Ethernet,SSP,UART,USART,EBI/EMI,I2S; Max Power Dissipation: 1.5W; Max Supply Voltage: 3.6V; Min Supply Voltage: 2.4V; Number of ADC Channels: 8; Number of Ethernet Channels: 1; Number of I2C Channels: 3; Number of I/Os: 109; Number of Programmable I/O: 165; Number of PWM Channels: 6; Number of Timers/Counters: 4; Number of UART Channels: 5; Operating Supply Voltage: 3.3V; Oscillator Type: Internal; Peripherals: Brown-out Detect/Reset,POR,PWM,WDT,DMA,I2C; RAM Size: 96KB; Watchdog Timer: Yes; Case/Package: LQFP; Component Kind: Standard; ComponentLink1Description: https://datasheet.ciiva.com/5700/1713929-5700204.pdf?src-supplier=Farnell; ComponentLink1URL: https://datasheet.ciiva.com/5700/1713929-5700204.pdf?src-supplier=Farnell; ComponentLink2Description: https://datasheet.ciiva.com/29721/lpc178x-7x-29721694.pdf?src-supplier=Digi-Key; ComponentLink2URL: https://datasheet.ciiva.com/29721/lpc178x-7x-29721694.pdf?src-supplier=Digi-Key; ComponentLink3Description: https://datasheet.ciiva.com/8010/1859465-8010171.pdf?src-supplier=Element14; ComponentLink3URL: https://datasheet.ciiva.com/8010/1859465-8010171.pdf?src-supplier=Element14; Contact Plating: Tin; Height: 1.45mm; Lead Free: Lead Free; Length: 20.1mm; Manufacturer Part Number: LPC1778FBD144,551; Max Frequency: 120MHz; Max Operating Temperature: 85°C; Memory Size: 512KB; Memory Type: FLASH; Min Operating Temperature: -40°C; Mount: Surface Mount; Number of Pins: 144; Number of SPI Channels: 3; Package Quantity: 60; Packaging: Tray; Pricing 1: 1=11.62000, 10=10.49800, 25=10.01000, 100=8.69170, 250=8.30112, 500=7.56866, 1000=6.59205 (USD); Radiation Hardening: No; REACH SVHC: No SVHC; RoHS: Yes; Stock 1: 0; Supplier 1: Digi-Key; Supplier Part Number 1: 568-7571-ND; Width: 20.1mm; Library Name: bitsnio.SchLib
U3 = IC_MCP6001T-I/OT: IC OPAMP GP 1MHZ RRO SOT23-5; Manufacturer 1: Microchip; Common Mode Rejection Ratio: 60dB; Input Bias Current: 1pA; Input Offset Voltage (Vos): 4.5mV; Max Supply Voltage: 6V; Min Supply Voltage: 1.8V; Nominal Supply Current: 100uA; Number of Amplifiers: 1; Operating Supply Voltage: 5.5V; Output Current: 23mA; Power Supply Rejection Ratio (PSRR): 86dB; Slew Rate: 0.6V/µs; Voltage Gain: 112dB; Bandwidth: 1MHz; Case/Package: SOT-23; Component Kind: Standard; ComponentLink1Description: https://datasheet.ciiva.com/1793/21733j-1793040.pdf; ComponentLink1URL: https://datasheet.ciiva.com/1793/21733j-1793040.pdf; ComponentLink2Description: https://datasheet.ciiva.com/16199/filehandler-aspxddocname-en011705-16199408.pdf; ComponentLink2URL: https://datasheet.ciiva.com/16199/filehandler-aspxddocname-en011705-16199408.pdf; ComponentLink3Description: https://datasheet.ciiva.com/26344/651487-da-01-en-ic-opamp-mcp6001t-i-ot-sot-23-5-mcp-26344500.pdf; ComponentLink3URL: https://datasheet.ciiva.com/26344/651487-da-01-en-ic-opamp-mcp6001t-i-ot-sot-23-5-mcp-26344500.pdf; ComponentLink4Description: https://datasheet.ciiva.com/1793/21733j-1793041.pdf; ComponentLink4URL: https://datasheet.ciiva.com/1793/21733j-1793041.pdf; ComponentLink5Description: https://datasheet.ciiva.com/1793/21733j-1793042.pdf; ComponentLink5URL: https://datasheet.ciiva.com/1793/21733j-1793042.pdf; ComponentLink6Description: https://datasheet.ciiva.com/16796/jp011705-16796421.pdf; ComponentLink6URL: https://datasheet.ciiva.com/16796/jp011705-16796421.pdf; ComponentLink7Description: https://datasheet.ciiva.com/8078/524878-8078826.pdf; ComponentLink7URL: https://datasheet.ciiva.com/8078/524878-8078826.pdf; ComponentLink8Description: https://datasheet.ciiva.com/14544/70045422-14544795.pdf; ComponentLink8URL: https://datasheet.ciiva.com/14544/70045422-14544795.pdf; ComponentLink9Description: https://datasheet.ciiva.com/8078/524878-8078829.pdf; ComponentLink9URL: https://datasheet.ciiva.com/8078/524878-8078829.pdf; ComponentLink10Description: https://datasheet.ciiva.com/14151/mcp6001-2-4-eng-tds-14151487.pdf; ComponentLink10URL: https://datasheet.ciiva.com/14151/mcp6001-2-4-eng-tds-14151487.pdf; Contact Plating: Tin,Matte; Gain Bandwidth Product: 1MHz; Height: 1.3mm; Lead Free: Lead Free; Length: 3.1mm; Manufacturer Part Number 1: MCP6001T-I/OT; Max Operating Temperature: 85°C; Min Operating Temperature: -40°C; Mount: Surface Mount; Number of Channels: 1; Number of Circuits: 1; Number of Elements: 1; Number of Pins: 5; Operating Supply Current: 100uA; Output Current per Channel: 23mA; Package Quantity: 1; Packaging: Tape and Reel; Pricing 1: 1=0.25, 25=0.206 (USD); Radiation Hardening: No; REACH SVHC: No SVHC; Stock 1: 3412; Supplier 1: Digi-Key; Supplier Part Number 1: MCP6001T-I/OTCT-ND; Unity Gain Bandwidth Product: 1MHz; Voltage: 6V; Width: 1.8mm; Library Name: bitsnio.SchLib
Y1 = ECS-120-20-30B-AGL-TR: CRYSTAL 12MHZ 20PF SMD; Manufacturer 1: ECS International; ESR (Equivalent Series Resistance): 80R; Frequency Stability: 30ppm; Frequency Tolerance: 25ppm; Case/Package: SMD/SMT; Component Kind: Standard; ComponentLink1Description: http://www.ecsxtal.com/store/pdf/ecx_53b.pdf?src-supplier=Digi-Key; ComponentLink1URL: http://www.ecsxtal.com/store/pdf/ecx_53b.pdf?src-supplier=Digi-Key; Frequency: 12MHz; Load Capacitance: 20pF; Manufacturer Part Number: ECS-120-20-30B-AGL-TR; Max Operating Temperature: 70°C; Min Operating Temperature: -10°C; Mount: Surface Mount; Packaging: Cut Tape; Pricing 1: 1=0.63000, 10=0.52300, 50=0.47020, 100=0.41800, 500=0.39710 (USD); RoHS: No; Stock 1: 1011; Supplier 1: Digi-Key; Supplier Part Number 1: XC2731CT-ND; Library Name: bitsnio.SchLib
